# Altium schematic sheet 核心板.SchDoc(核心板)
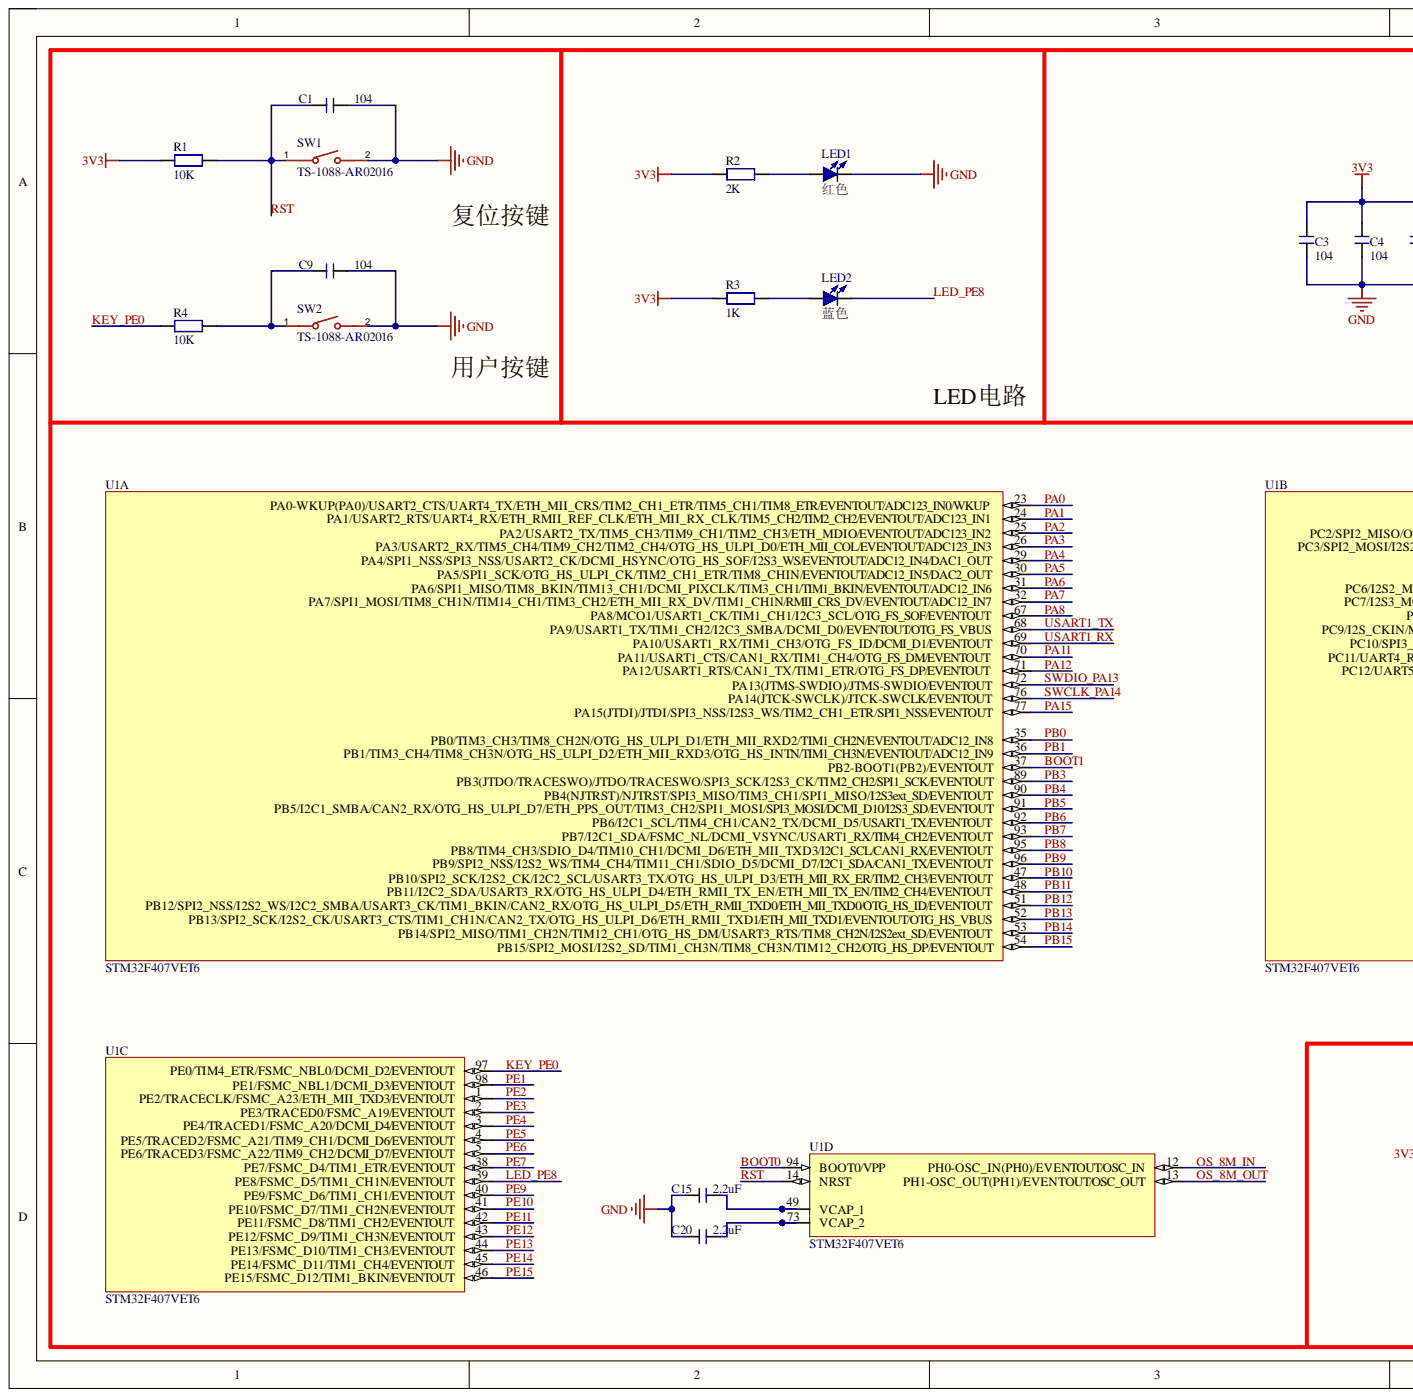
[diagram p0: 核心板.SchDoc, left]
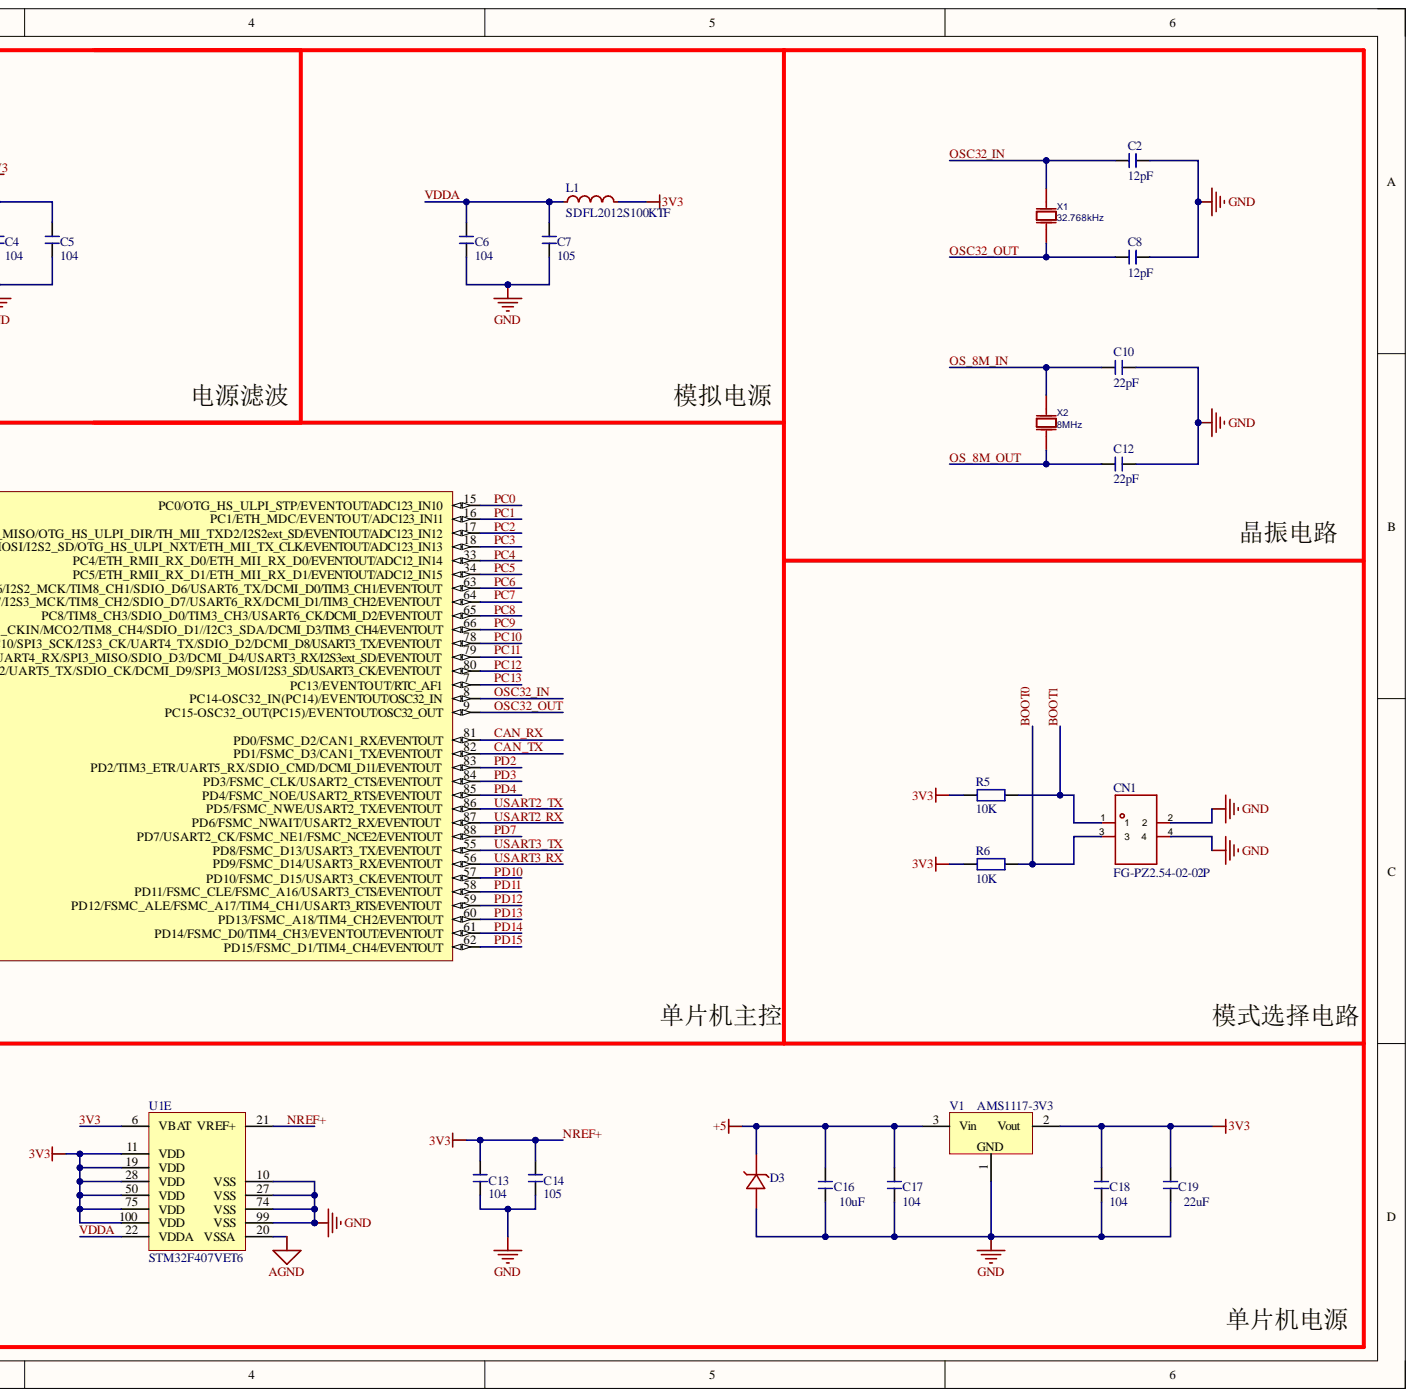
[diagram p1: 核心板.SchDoc, right]

## Parts
C1  104  [C 0805_L]
C2  12pF  [C 0805_L]
C3  104  [C 0805_L]
C4  104  [C 0805_L]
C5  104  [C 0805_L]
C6  104  [C 0805_L]
C7  105  [C 0805_L]
C8  12pF  [C 0805_L]
C9  104  [C 0805_L]
C10  22pF  [C 0805_L]
C12  22pF  [C 0805_L]
C13  104  [C 0805_L]
C14  105  [C 0805_L]
C15  2.2uF  [C 0805_L]
C16  10uF  [C 0805_L]
C17  104  [C 0805_L]
C18  104  [C 0805_L]
C19  22uF  [C 0805_L]
C20  2.2uF  [C 0805_L]
CN1  FG-PZ2.54-02-02P  [HDR-TH_4P-P2.54-V-M-R2-C2-S2.54]
D3  LESD5Z5.0T1G  [SOD-523_L1.2-W0.8-LS1.6-RD]  (value not drawn)
L1  SDFL2012S100KTF  [L0805]
LED1  红色  [LED 0805G]
LED2  蓝色  [LED 0805G]
R1  10K  [R 0805_L]
R2  2K  [R 0805_L]
R3  1K  [R 0805_L]
R4  10K  [R 0805_L]
R5  10K  [R 0805_L]
R6  10K  [R 0805_L]
SW1  TS-1088-AR02016  [SW-SMD_L3.9-W3.0-P4.45]
SW2  TS-1088-AR02016  [SW-SMD_L3.9-W3.0-P4.45]
U1  STM32F407VET6  [LQFP100_V]  (parts A,B,C,D,E)
V1  AMS1117-3V3  [SOT223_L]
X1  32.768kHz  [CRYSTAL-SMD_L3.2-W1.5-1]
X2  8MHz  [CRYSTAL-SMD_L5.0-W3.2]

## Nets
+5: C16.2 C17.2 D3.1 V1.3
AGND: U1.20(VSSA)
GND: C1.2 C2.2 C3.1 C4.1 C5.1 C6.1 C7.1 C8.2 C9.2 C10.2 C12.2 C13.1 C14.1 C15.1 C16.1 C17.1 C18.1 C19.1 C20.1 CN1.2 CN1.4 D3.2 LED1.2 SW1.2 SW2.2 U1.10(VSS) U1.27(VSS) U1.74(VSS) U1.99(VSS) V1.1
3V3: C3.2 C4.2 C5.2 C13.2 C14.2 C18.2 C19.2 L1.2 R1.1 R2.1 R3.1 R5.1 R6.1 U1.6(VBAT) U1.11(VDD) U1.19(VDD) U1.21(VREF+) U1.28(VDD) U1.50(VDD) U1.75(VDD) U1.100(VDD) V1.2
BOOT0: CN1.3 R6.2 U1.94(BOOT0/VPP)
BOOT1: CN1.1 R5.2 U1.37(PB2-BOOT1(PB2)/EVENTOUT)
CAN_RX: U1.81(PD0/FSMC_D2/CAN1_RX/EVENTOUT)
CAN_TX: U1.82(PD1/FSMC_D3/CAN1_TX/EVENTOUT)
KEY_PE0: R4.1 U1.97(PE0/TIM4_ETR/FSMC_NBL0/DCMI_D2/EVENTOUT)
LED_PE8: LED2.2 U1.39(PE8/FSMC_D5/TIM1_CH1N/EVENTOUT)
OS_8M_IN: C10.1 U1.12(PH0-OSC_IN(PH0)/EVENTOUT/OSC_IN) X2.2
OS_8M_OUT: C12.1 U1.13(PH1-OSC_OUT(PH1)/EVENTOUT/OSC_OUT) X2.1
OSC32_IN: C2.1 U1.8(PC14-OSC32_IN(PC14)/EVENTOUT/OSC32_IN) X1.2
OSC32_OUT: C8.1 U1.9(PC15-OSC32_OUT(PC15)/EVENTOUT/OSC32_OUT) X1.1
PA0: U1.23(PA0-WKUP(PA0)/USART2_CTS/UART4_TX/ETH_MII_CRS/TIM2_CH1_ETR/TIM5_CH1/TIM8_ETR/EVENTOUT/ADC123_IN0/WKUP)
PA1: U1.24(PA1/USART2_RTS/UART4_RX/ETH_RMII_REF_CLK/ETH_MII_RX_CLK/TIM5_CH2/TIM2_CH2/EVENTOUT/ADC123_IN1)
PA2: U1.25(PA2/USART2_TX/TIM5_CH3/TIM9_CH1/TIM2_CH3/ETH_MDIO/EVENTOUT/ADC123_IN2)
PA3: U1.26(PA3/USART2_RX/TIM5_CH4/TIM9_CH2/TIM2_CH4/OTG_HS_ULPI_D0/ETH_MII_COL/EVENTOUT/ADC123_IN3)
PA4: U1.29(PA4/SPI1_NSS/SPI3_NSS/USART2_CK/DCMI_HSYNC/OTG_HS_SOF/I2S3_WS/EVENTOUT/ADC12_IN4/DAC1_OUT)
PA5: U1.30(PA5/SPI1_SCK/OTG_HS_ULPI_CK/TIM2_CH1_ETR/TIM8_CHIN/EVENTOUT/ADC12_IN5/DAC2_OUT)
PA6: U1.31(PA6/SPI1_MISO/TIM8_BKIN/TIM13_CH1/DCMI_PIXCLK/TIM3_CH1/TIM1_BKIN/EVENTOUT/ADC12_IN6)
PA7: U1.32(PA7/SPI1_MOSI/TIM8_CH1N/TIM14_CH1/TIM3_CH2/ETH_MII_RX_DV/TIM1_CH1N/RMII_CRS_DV/EVENTOUT/ADC12_IN7)
PA8: U1.67(PA8/MCO1/USART1_CK/TIM1_CH1/I2C3_SCL/OTG_FS_SOF/EVENTOUT)
PA11: U1.70(PA11/USART1_CTS/CAN1_RX/TIM1_CH4/OTG_FS_DM/EVENTOUT)
PA12: U1.71(PA12/USART1_RTS/CAN1_TX/TIM1_ETR/OTG_FS_DP/EVENTOUT)
PA15: U1.77(PA15(JTDI)/JTDI/SPI3_NSS/I2S3_WS/TIM2_CH1_ETR/SPI1_NSS/EVENTOUT)
PB0: U1.35(PB0/TIM3_CH3/TIM8_CH2N/OTG_HS_ULPI_D1/ETH_MII_RXD2/TIM1_CH2N/EVENTOUT/ADC12_IN8)
PB1: U1.36(PB1/TIM3_CH4/TIM8_CH3N/OTG_HS_ULPI_D2/ETH_MII_RXD3/OTG_HS_INTN/TIM1_CH3N/EVENTOUT/ADC12_IN9)
PB3: U1.89(PB3(JTDO/TRACESWO)/JTDO/TRACESWO/SPI3_SCK/I2S3_CK/TIM2_CH2/SPI1_SCK/EVENTOUT)
PB4: U1.90(PB4(NJTRST)/NJTRST/SPI3_MISO/TIM3_CH1/SPI1_MISO/I2S3ext_SD/EVENTOUT)
PB5: U1.91(PB5/I2C1_SMBA/CAN2_RX/OTG_HS_ULPI_D7/ETH_PPS_OUT/TIM3_CH2/SPI1_MOSI/SPI3_MOSI/DCMI_D10/I2S3_SD/EVENTOUT)
PB6: U1.92(PB6/I2C1_SCL/TIM4_CH1/CAN2_TX/DCMI_D5/USART1_TX/EVENTOUT)
PB7: U1.93(PB7/I2C1_SDA/FSMC_NL/DCMI_VSYNC/USART1_RX/TIM4_CH2/EVENTOUT)
PB8: U1.95(PB8/TIM4_CH3/SDIO_D4/TIM10_CH1/DCMI_D6/ETH_MII_TXD3/I2C1_SCL/CAN1_RX/EVENTOUT)
PB9: U1.96(PB9/SPI2_NSS/I2S2_WS/TIM4_CH4/TIM11_CH1/SDIO_D5/DCMI_D7/I2C1_SDA/CAN1_TX/EVENTOUT)
PB10: U1.47(PB10/SPI2_SCK/I2S2_CK/I2C2_SCL/USART3_TX/OTG_HS_ULPI_D3/ETH_MII_RX_ER/TIM2_CH3/EVENTOUT)
PB11: U1.48(PB11/I2C2_SDA/USART3_RX/OTG_HS_ULPI_D4/ETH_RMII_TX_EN/ETH_MII_TX_EN/TIM2_CH4/EVENTOUT)
PB12: U1.51(PB12/SPI2_NSS/I2S2_WS/I2C2_SMBA/USART3_CK/TIM1_BKIN/CAN2_RX/OTG_HS_ULPI_D5/ETH_RMII_TXD0/ETH_MII_TXD0/OTG_HS_ID/EVENTOUT)
PB13: U1.52(PB13/SPI2_SCK/I2S2_CK/USART3_CTS/TIM1_CH1N/CAN2_TX/OTG_HS_ULPI_D6/ETH_RMII_TXD1/ETH_MII_TXD1/EVENTOUT/OTG_HS_VBUS)
PB14: U1.53(PB14/SPI2_MISO/TIM1_CH2N/TIM12_CH1/OTG_HS_DM/USART3_RTS/TIM8_CH2N/I2S2ext_SD/EVENTOUT)
PB15: U1.54(PB15/SPI2_MOSI/I2S2_SD/TIM1_CH3N/TIM8_CH3N/TIM12_CH2/OTG_HS_DP/EVENTOUT)
PC0: U1.15(PC0/OTG_HS_ULPI_STP/EVENTOUT/ADC123_IN10)
PC1: U1.16(PC1/ETH_MDC/EVENTOUT/ADC123_IN11)
PC2: U1.17(PC2/SPI2_MISO/OTG_HS_ULPI_DIR/TH_MII_TXD2/I2S2ext_SD/EVENTOUT/ADC123_IN12)
PC3: U1.18(PC3/SPI2_MOSI/I2S2_SD/OTG_HS_ULPI_NXT/ETH_MII_TX_CLK/EVENTOUT/ADC123_IN13)
PC4: U1.33(PC4/ETH_RMII_RX_D0/ETH_MII_RX_D0/EVENTOUT/ADC12_IN14)
PC5: U1.34(PC5/ETH_RMII_RX_D1/ETH_MII_RX_D1/EVENTOUT/ADC12_IN15)
PC6: U1.63(PC6/I2S2_MCK/TIM8_CH1/SDIO_D6/USART6_TX/DCMI_D0/TIM3_CH1/EVENTOUT)
PC7: U1.64(PC7/I2S3_MCK/TIM8_CH2/SDIO_D7/USART6_RX/DCMI_D1/TIM3_CH2/EVENTOUT)
PC8: U1.65(PC8/TIM8_CH3/SDIO_D0/TIM3_CH3/USART6_CK/DCMI_D2/EVENTOUT)
PC9: U1.66(PC9/I2S_CKIN/MCO2/TIM8_CH4/SDIO_D1//I2C3_SDA/DCMI_D3/TIM3_CH4/EVENTOUT)
PC10: U1.78(PC10/SPI3_SCK/I2S3_CK/UART4_TX/SDIO_D2/DCMI_D8/USART3_TX/EVENTOUT)
PC11: U1.79(PC11/UART4_RX/SPI3_MISO/SDIO_D3/DCMI_D4/USART3_RX/I2S3ext_SD/EVENTOUT)
PC12: U1.80(PC12/UART5_TX/SDIO_CK/DCMI_D9/SPI3_MOSI/I2S3_SD/USART3_CK/EVENTOUT)
PC13: U1.7(PC13/EVENTOUT/RTC_AF1)
PD2: U1.83(PD2/TIM3_ETR/UART5_RX/SDIO_CMD/DCMI_D11/EVENTOUT)
PD3: U1.84(PD3/FSMC_CLK/USART2_CTS/EVENTOUT)
PD4: U1.85(PD4/FSMC_NOE/USART2_RTS/EVENTOUT)
PD7: U1.88(PD7/USART2_CK/FSMC_NE1/FSMC_NCE2/EVENTOUT)
PD10: U1.57(PD10/FSMC_D15/USART3_CK/EVENTOUT)
PD11: U1.58(PD11/FSMC_CLE/FSMC_A16/USART3_CTS/EVENTOUT)
PD12: U1.59(PD12/FSMC_ALE/FSMC_A17/TIM4_CH1/USART3_RTS/EVENTOUT)
PD13: U1.60(PD13/FSMC_A18/TIM4_CH2/EVENTOUT)
PD14: U1.61(PD14/FSMC_D0/TIM4_CH3/EVENTOUT/EVENTOUT)
PD15: U1.62(PD15/FSMC_D1/TIM4_CH4/EVENTOUT)
PE1: U1.98(PE1/FSMC_NBL1/DCMI_D3/EVENTOUT)
PE2: U1.1(PE2/TRACECLK/FSMC_A23/ETH_MII_TXD3/EVENTOUT)
PE3: U1.2(PE3/TRACED0/FSMC_A19/EVENTOUT)
PE4: U1.3(PE4/TRACED1/FSMC_A20/DCMI_D4/EVENTOUT)
PE5: U1.4(PE5/TRACED2/FSMC_A21/TIM9_CH1/DCMI_D6/EVENTOUT)
PE6: U1.5(PE6/TRACED3/FSMC_A22/TIM9_CH2/DCMI_D7/EVENTOUT)
PE7: U1.38(PE7/FSMC_D4/TIM1_ETR/EVENTOUT)
PE9: U1.40(PE9/FSMC_D6/TIM1_CH1/EVENTOUT)
PE10: U1.41(PE10/FSMC_D7/TIM1_CH2N/EVENTOUT)
PE11: U1.42(PE11/FSMC_D8/TIM1_CH2/EVENTOUT)
PE12: U1.43(PE12/FSMC_D9/TIM1_CH3N/EVENTOUT)
PE13: U1.44(PE13/FSMC_D10/TIM1_CH3/EVENTOUT)
PE14: U1.45(PE14/FSMC_D11/TIM1_CH4/EVENTOUT)
PE15: U1.46(PE15/FSMC_D12/TIM1_BKIN/EVENTOUT)
RST: C1.1 R1.2 SW1.1 U1.14(NRST)
SWCLK_PA14: U1.76(PA14(JTCK-SWCLK)/JTCK-SWCLK/EVENTOUT)
SWDIO_PA13: U1.72(PA13(JTMS-SWDIO)/JTMS-SWDIO/EVENTOUT)
USART1_RX: U1.69(PA10/USART1_RX/TIM1_CH3/OTG_FS_ID/DCMI_D1/EVENTOUT)
USART1_TX: U1.68(PA9/USART1_TX/TIM1_CH2/I2C3_SMBA/DCMI_D0/EVENTOUT/OTG_FS_VBUS)
USART2_RX: U1.87(PD6/FSMC_NWAIT/USART2_RX/EVENTOUT)
USART2_TX: U1.86(PD5/FSMC_NWE/USART2_TX/EVENTOUT)
USART3_RX: U1.56(PD9/FSMC_D14/USART3_RX/EVENTOUT)
USART3_TX: U1.55(PD8/FSMC_D13/USART3_TX/EVENTOUT)
VDDA: C6.2 C7.2 L1.1 U1.22(VDDA)
NetC9_1: C9.1 R4.2 SW2.1
NetC15_2: C15.2 U1.49(VCAP_1)
NetC20_2: C20.2 U1.73(VCAP_2)
NetLED1_1: LED1.1 R2.2
NetLED2_1: LED2.1 R3.2

## Library data of the parts
C1-C10 C12-C20 = C: 贴片电容; BOM名称: 无极性贴片电容; 淘宝ID: 封装库来自淘宝 ID：黄晶宝石; Component Kind: Standard; Library Name: 0.1 - 电阻-电容-电感.SchLib; 唯一出售店铺网址: https://shop463601444.taobao.com; 联系售后，技术支持: https://shop463601444.taobao.com
CN1 = FG-PZ2.54-02-02P: 2*2P排针; Component Kind: Standard; Library Name: 8.1 - 排针-脚距2.54.SchLib
D3 = LESD5Z5.0T1G: 5.1V稳压二极管; Component Kind: Standard; Library Name: 0.2 - 二极管-整流桥.SchLib
L1 = SDFL2012S100KTF: 0805贴片电感; Component Kind: Standard; Library Name: 0.1 - 电阻-电容-电感.SchLib
LED1 LED2 = LED-SMD: 贴片LED; 版权归属: 封装库来自淘宝 ID：黄晶宝石; BOM名称: 贴片LED; Component Kind: Standard; Library Name: 0.3 - LED发光二极管.SchLib; 学习资源: https://shop463601444.taobao.com
R1-R6 = R: 贴片电阻; 封装库来自淘宝卖家 ID：黄晶宝石: 其他店铺购入均为盗版，不享受售后技术支持服务，请立即申请退款; 版权归属: 来自淘宝 ID：黄晶宝石; BOM名称: 贴片电阻; Component Kind: Standard; Library Name: 0.1 - 电阻-电容-电感.SchLib; 联系售后: https://shop463601444.taobao.com
SW1 SW2 = TS-1088-AR02016: 单脚小龟轻触开关; Component Kind: Standard; Library Name: 0.7 - 常用按键-开关.SchLib
U1 = STM32F407VET6: ARM Cortex-M4 32b MCU+FPU, 210DMIP, 512 kB Flash, 192+4 kB RAM, Camera, 82 I/Os, 100-pin LQFP, -40 to +85癈, Tray; 16-bit Timers (IC/OC/PWM): 12x16-bit(24/24/30); 32-bit Timer (IC/OC/PWM): 2x32-bit (8/8/8); A/D Converter: 16x12-bit; D/A Converter: 2x12-bit; Internal RAM: 192kB; Operating Frequency (Processor Speed): 168MHz; Other Timer Functions: 2xWDG, RTC, 24-bit down counter; Serial Interface: 3xSPI/2xI2S/2xI2C/3xUSART(IrDa, ISO7816)/3xUART/2xUSB OTG FS/HS, 2xCAN/Ethernet MAC10/100, SDIO; Component Kind: Standard; ComponentLink1Description: Manufacturer URL; ComponentLink1URL: http://www.st.com/; ComponentLink2Description: STM32F405/7 Datasheet; ComponentLink2URL: http://www.st.com/internet/com/TECHNICAL_RESOURCES/TECHNICAL_LITERATURE/DATASHEET/DM00037051.pdf; ComponentLink3Description: STM32F4 Web Page; ComponentLink3URL: http://www.st.com/internet/mcu/subclass/1521.jsp; DatasheetVersion: rev2, Jan-2012; Library Name: 2.5 - STM32 F4系列单片机.SchLib; PackageDescription: 100-Pin Low Profile Quad Flat Package, 14x14 mm Body, 0.5 mm Pitch; PackageReference: LQFP100; PackageVersion: 2012
V1 = AMS1117: 三端稳压芯片; BOM名称: 稳压芯片; 淘宝ID: 封装库来自淘宝 ID：黄晶宝石; Component Kind: Standard; Library Name: 1.1 - 电源类芯片.SchLib; 欢迎光临淘宝店铺: https://shop463601444.taobao.com
X1 = XKXGI-SUA-32.768K: 晶振类型:贴片晶振;频率:32.768kHz;常温频差:±20ppm;负载电容:12.5pF;频率稳定度:±30ppm;; Manufacturer: YXC(扬兴晶振); AddInBom: yes; Equivalent Series Resistance(ESR): 70kΩ; Frequency Stability: ±30ppm; Load Capacitance: 12.5pF; ModelId: 762d7afc596f4558a373612390f4386d聨0819f05c4eef4c71ace90d822a990e87; ModelTransform: 125.984,59.055,0,0,0,0,0,0,0; Normal temperature Frequency Tolerance: ±20ppm; Component Kind: Standard; ConvertToPcb: yes; Datasheet: https://item.szlcsc.com/datasheet/XKXGI-SUA-32.768K/5908943.html; Device: XKXGI-SUA-32.768K; FootprintName: CRYSTAL-SMD_L3.2-W1.5-1; JLCPCB Part Class: Extended Part; LCSC Part Name: YST310S 32.768KHZ 2P 3215 12.5PF ±20PPM -40~85℃; Library Name: 2.0 - 保险丝-晶振-光耦.SchLib; ManufacturerPart: XKXGI-SUA-32.768K; ModelName: CRYSTAL-SMD_L3.2-W1.5-1; Operating Temperature: -40℃~+85℃; Supplier: LCSC; Supplier Footprint: SMD3215-2P; SupplierPart: C5213671; Symbol: XKXGI-SUA-32.768K; Type: 贴片晶振; Value: 32.768kHz
X2 = X50328MSB2GI: 晶振类型:贴片晶振;频率:8MHz;常温频差:±10ppm;负载电容:20pF;频率稳定度:±20ppm;; Manufacturer: YXC(扬兴晶振); AddInBom: yes; Equivalent Series Resistance(ESR): 80Ω; Frequency Stability: ±20ppm; Load Capacitance: 20pF; ModelId: d7c39c66443246ff83c2003c1e1eb6f1聨0819f05c4eef4c71ace90d822a990e87; ModelTransform: 196.85,125.984,0,0,0,0,0,0,0; Normal temperature Frequency Tolerance: ±10ppm; Component Kind: Standard; ConvertToPcb: yes; Datasheet: https://item.szlcsc.com/datasheet/X50328MSB2GI/117214.html; Device: X50328MSB2GI; FootprintName: CRYSTAL-SMD_L5.0-W3.2; JLCPCB Part Class: Basic Part; LCSC Part Name: YSX530GA 8MHZ 2P 5032 20PF ±10PPM -40~85℃ (±20PPM); Library Name: 2.0 - 保险丝-晶振-光耦.SchLib; ManufacturerPart: X50328MSB2GI; ModelName: CRYSTAL-SMD_L5.0-W3.2; Operating Temperature: -40℃~+85℃; Supplier: LCSC; Supplier Footprint: SMD5032-2P; SupplierPart: C115962; Symbol: X50328MSB2GI; Type: 贴片晶振; Value: 8MHz
